# Altium schematic sheet Battery Manager.SchDoc
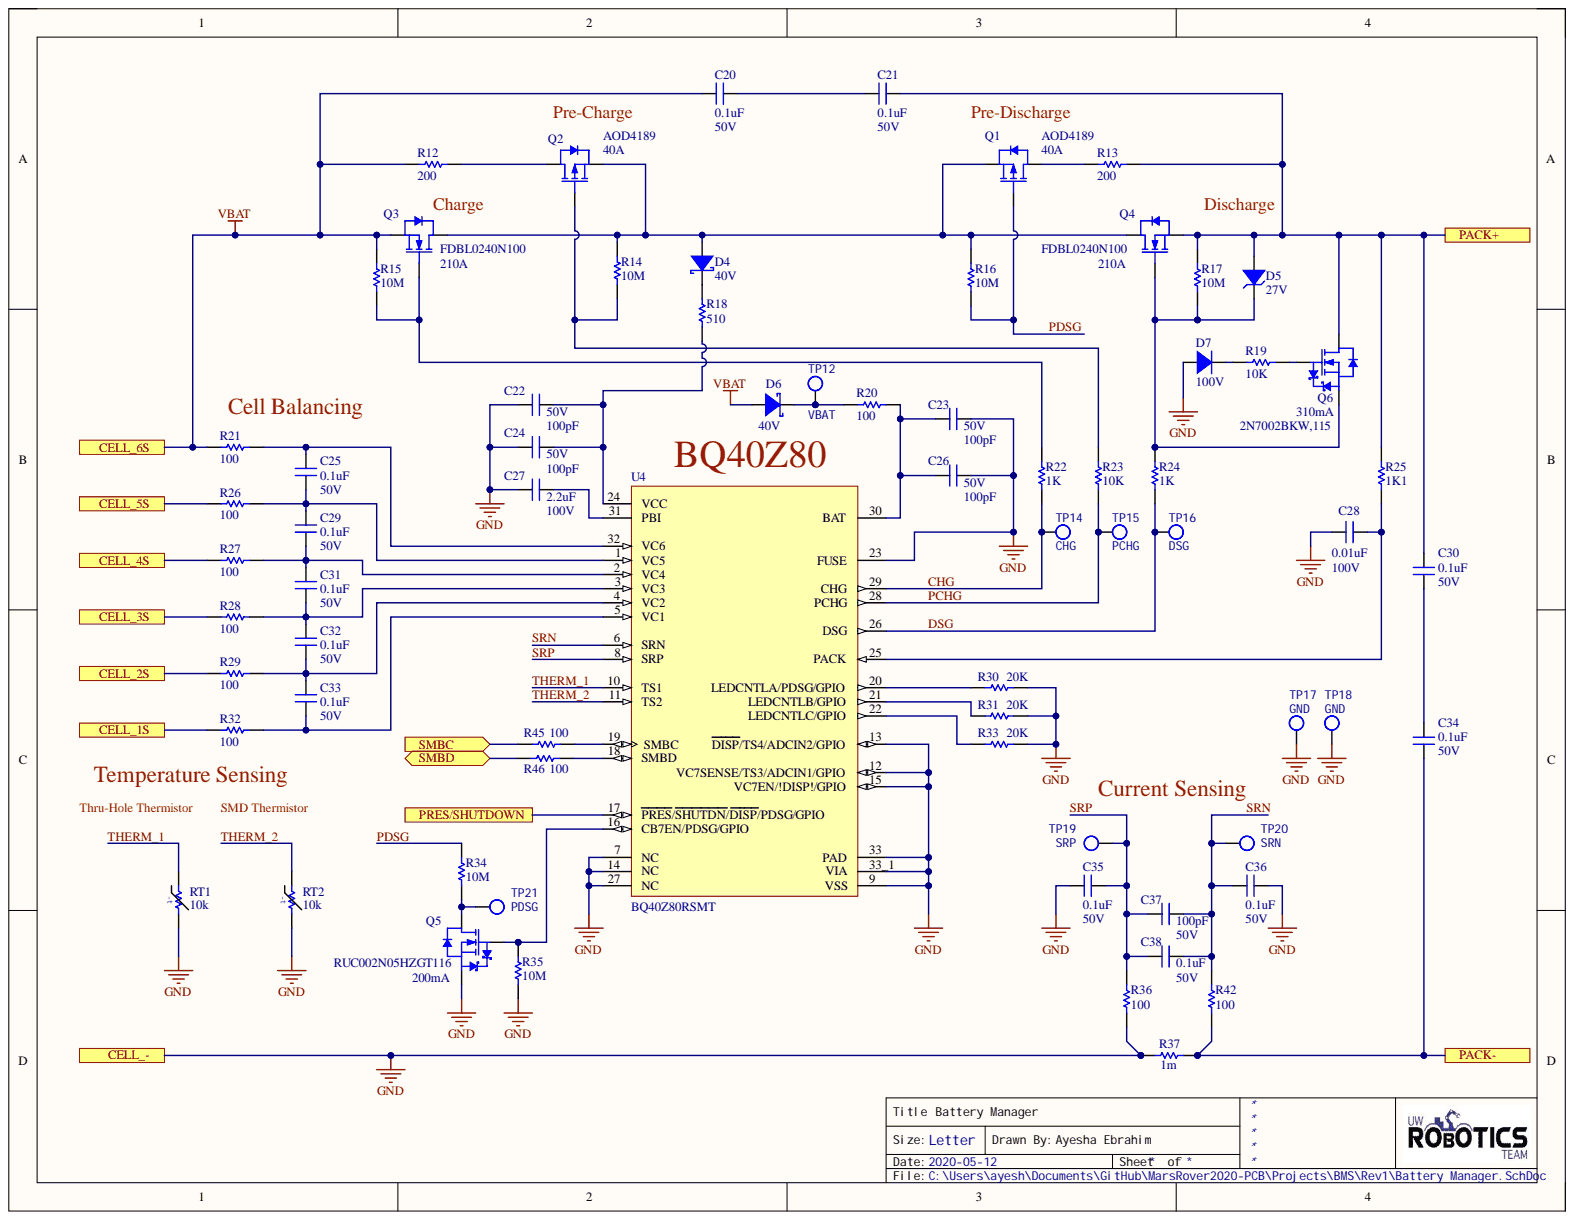
[diagram p0: Battery Manager.SchDoc]

## Parts
C20  value 0.1uF  Voltage Rating: 50V  [CAPC2012X140N]  (hidden comment: CAP_0.1uF_50V_0805)
C21  value 0.1uF  Voltage Rating: 50V  [CAPC2012X140N]  (hidden comment: CAP_0.1uF_50V_0805)
C22  value 100pF  Capacitance: 100pF  Voltage Rating: 50V  [CAPC2012X140N]  (hidden comment: CAP_100pF_50V_0805)
C23  value 100pF  Capacitance: 100pF  Voltage Rating: 50V  [CAPC2012X140N]  (hidden comment: CAP_100pF_50V_0805)
C24  value 100pF  Capacitance: 100pF  Voltage Rating: 50V  [CAPC2012X140N]  (hidden comment: CAP_100pF_50V_0805)
C25  value 0.1uF  Voltage Rating: 50V  [CAPC2012X140N]  (hidden comment: CAP_0.1uF_50V_0805)
C26  value 100pF  Capacitance: 100pF  Voltage Rating: 50V  [CAPC2012X140N]  (hidden comment: CAP_100pF_50V_0805)
C27  value 2.2uF  Capacitance: 2.2uF  Voltage Rating: 100V  [CAPC1210(3225)]  (hidden comment: CAP_2.2uF_100V_1210)
C28  value 0.01uF  Voltage Rating: 100V  [CAPC2012X140N]  (hidden comment: CAP_0.01uF_100V_0805)
C29  value 0.1uF  Voltage Rating: 50V  [CAPC2012X140N]  (hidden comment: CAP_0.1uF_50V_0805)
C30  value 0.1uF  Voltage Rating: 50V  [CAPC2012X140N]  (hidden comment: CAP_0.1uF_50V_0805)
C31  value 0.1uF  Voltage Rating: 50V  [CAPC2012X140N]  (hidden comment: CAP_0.1uF_50V_0805)
C32  value 0.1uF  Voltage Rating: 50V  [CAPC2012X140N]  (hidden comment: CAP_0.1uF_50V_0805)
C33  value 0.1uF  Voltage Rating: 50V  [CAPC2012X140N]  (hidden comment: CAP_0.1uF_50V_0805)
C34  value 0.1uF  Voltage Rating: 50V  [CAPC2012X140N]  (hidden comment: CAP_0.1uF_50V_0805)
C35  value 0.1uF  Voltage Rating: 50V  [CAPC2012X140N]  (hidden comment: CAP_0.1uF_50V_0805)
C36  value 0.1uF  Voltage Rating: 50V  [CAPC2012X140N]  (hidden comment: CAP_0.1uF_50V_0805)
C37  value 100pF  Capacitance: 100pF  Voltage Rating: 50V  [CAPC2012X140N]  (hidden comment: CAP_100pF_50V_0805)
C38  value 0.1uF  Voltage Rating: 50V  [CAPC2012X140N]  (hidden comment: CAP_0.1uF_50V_0805)
D4  Max Repetitive Reverse Voltage: 40V  [SOD123]  (hidden comment: B0540W-7-F)
D5  Working Voltage: 27V  [SOT23-3]  (hidden comment: DIO_ZENER_27V)
D6  Max Repetitive Reverse Voltage: 40V  [SOD123]  (hidden comment: B0540W-7-F)
D7  value 100V  [SOD123]  (hidden comment: 1N4148W-7-F)
Q1  Id: 40A  Manufacturer Part Number 1: AOD4189  [D-PAK]  (hidden comment: PMOS_40V_40A)
Q2  Id: 40A  Manufacturer Part Number 1: AOD4189  [D-PAK]  (hidden comment: PMOS_40V_40A)
Q3  Id: 210A  Manufacturer Part Number 1: FDBL0240N100  [8-HPSOF]  (hidden comment: NMOS_100V_210A)
Q4  Id: 210A  Manufacturer Part Number 1: FDBL0240N100  [8-HPSOF]  (hidden comment: NMOS_100V_210A)
Q5  Id: 200mA  Manufacturer Part Number 1: RUC002N05HZGT116  [SOT23-3]  (hidden comment: NMOS_50V_200mA)
Q6  Id: 310mA  Manufacturer Part Number 1: 2N7002BKW,115  [SOT323]  (hidden comment: NMOS_60V_310mA)
R12  value 200  [RESC6532X75N]  (hidden comment: RES_200R_2512)
R13  value 200  [RESC6532X75N]  (hidden comment: RES_200R_2512)
R14  value 10M  [RESC2012X60N]  (hidden comment: RES_10M_0805)
R15  value 10M  [RESC2012X60N]  (hidden comment: RES_10M_0805)
R16  value 10M  [RESC2012X60N]  (hidden comment: RES_10M_0805)
R17  value 10M  [RESC2012X60N]  (hidden comment: RES_10M_0805)
R18  value 510  [RESC2012X60N]  (hidden comment: RES_510R_0805)
R19  value 10K  Resistance: 10K  [RESC2012X60N]  (hidden comment: RES_10K_0805)
R20  value 100  [RESC2012X60N]  (hidden comment: RES_100R_0805)
R21  value 100  [RESC2012X60N]  (hidden comment: RES_100R_0805)
R22  value 1K  Resistance: 1K  [RESC2012X60N]  (hidden comment: RES_1K_0805)
R23  value 10K  Resistance: 10K  [RESC2012X60N]  (hidden comment: RES_10K_0805)
R24  value 1K  Resistance: 1K  [RESC2012X60N]  (hidden comment: RES_1K_0805)
R25  value 1K1  Resistance: 1K1  [RESC2012X60N]  (hidden comment: RES_1K1_0805)
R26  value 100  [RESC2012X60N]  (hidden comment: RES_100R_0805)
R27  value 100  [RESC2012X60N]  (hidden comment: RES_100R_0805)
R28  value 100  [RESC2012X60N]  (hidden comment: RES_100R_0805)
R29  value 100  [RESC2012X60N]  (hidden comment: RES_100R_0805)
R30  value 20K  Resistance: 20K  [RESC2012X60N]  (hidden comment: RES_20K_0805)
R31  value 20K  Resistance: 20K  [RESC2012X60N]  (hidden comment: RES_20K_0805)
R32  value 100  [RESC2012X60N]  (hidden comment: RES_100R_0805)
R33  value 20K  Resistance: 20K  [RESC2012X60N]  (hidden comment: RES_20K_0805)
R34  value 10M  [RESC2012X60N]  (hidden comment: RES_10M_0805)
R35  value 10M  [RESC2012X60N]  (hidden comment: RES_10M_0805)
R36  value 100  [RESC2012X60N]  (hidden comment: RES_100R_0805)
R37  value 1m  [RES-5931]  (hidden comment: RES_1m_5931)
R42  value 100  [RESC2012X60N]  (hidden comment: RES_100R_0805)
R45  value 100  [RESC2012X60N]  (hidden comment: RES_100R_0805)
R46  value 100  [RESC2012X60N]  (hidden comment: RES_100R_0805)
RT1  Resistance: 10k  [PTH_THERMISTOR]  (hidden comment: THERM_10k_FREE_HANGING)
RT2  Resistance: 10k  [RESC0603(1608)_N]  (hidden comment: THERM_10k_0603)
TP12  VBAT  [TESTPOINT_LOOP]
TP14  CHG  [TESTPOINT_LOOP]
TP15  PCHG  [TESTPOINT_LOOP]
TP16  DSG  [TESTPOINT_LOOP]
TP17  GND  [TESTPOINT_LOOP]
TP18  GND  [TESTPOINT_LOOP]
TP19  SRP  [TESTPOINT_LOOP]
TP20  SRN  [TESTPOINT_LOOP]
TP21  PDSG  [TESTPOINT_LOOP]
U4  BQ40Z80RSMT  [BQ40Z80RSMT]

## Ports
CELL_1S, CELL_2S, CELL_3S, CELL_4S, CELL_5S, CELL_6S, CELL_-, PACK+, PACK-, PRES/SHUTDOWN, SMBC, SMBD

## Nets
CELL_1S: R32.1 ; port CELL_1S
CELL_2S: R29.1 ; port CELL_2S
CELL_3S: R28.1 ; port CELL_3S
CELL_4S: R27.1 ; port CELL_4S
CELL_5S: R26.1 ; port CELL_5S
CHG: R22.1 TP14.1 U4.29(CHG)
DSG: R24.1 TP16.1 U4.26(DSG)
GND: C22.1 C23.2 C24.1 C26.2 C27.1 C28.1 C35.1 C36.2 D7.2 Q5.2 R30.2 R31.2 R33.2 R35.1 R36.1 R37.1 RT1.2 RT2.2 TP17.1 TP18.1 U4.7(NC) U4.9(VSS) U4.12(VC7SENSE/TS3/ADCIN1/GPIO) U4.13(DISP/TS4/ADCIN2/GPIO) U4.14(NC) U4.15(VC7EN/!DISP!/GPIO) U4.23(FUSE) U4.27(NC) U4.33(PAD) U4.33_1(VIA) ; port CELL_-
PACK+: C21.2 C30.2 D5.1 Q4.2 Q6.4 R13.2 R17.2 R25.2 ; port PACK+
PACK-: C34.1 R37.2 R42.1 ; port PACK-
PCHG: R23.1 TP15.1 U4.28(PCHG)
PDSG: Q1.2 R16.1 R34.2
PRES/SHUTDOWN: U4.17(PRES/SHUTDN/DISP/PDSG/GPIO) ; port PRES/SHUTDOWN
SMBC: R45.1 ; port SMBC
SMBD: R46.1 ; port SMBD
SRN: C36.1 C37.2 C38.2 R42.2 TP20.1 U4.6(SRN)
SRP: C35.2 C37.1 C38.1 R36.2 TP19.1 U4.8(SRP)
THERM_1: RT1.1 U4.10(TS1)
THERM_2: RT2.1 U4.11(TS2)
VBAT: C20.1 D6.2 Q3.2 R12.1 R15.2 R21.1 ; port CELL_6S
NetC20_2: C20.2 C21.1
NetC22_2: C22.2 C24.2 R18.1 U4.24(VCC)
NetC23_1: C23.1 C26.1 R20.2 U4.30(BAT)
NetC25_1: C25.1 C29.2 R26.2 U4.1(VC5)
NetC25_2: C25.2 R21.2 U4.32(VC6)
NetC27_2: C27.2 U4.31(PBI)
NetC28_2: C28.2 R25.1 U4.25(PACK)
NetC29_1: C29.1 C31.2 R27.2 U4.2(VC4)
NetC30_1: C30.1 C34.2
NetC31_1: C31.1 C32.2 R28.2 U4.3(VC3)
NetC32_1: C32.1 C33.2 R29.2 U4.4(VC2)
NetC33_1: C33.1 R32.2 U4.5(VC1)
NetD4_1: D4.1 R18.2
NetD4_2: D4.2 Q1.3 Q2.3 Q3.3 Q4.3 R14.2 R16.2
NetD5_3: D5.3 Q4.1 Q6.3 R17.1 R24.2
NetD6_1: D6.1 R20.1 TP12.1
NetD7_1: D7.1 R19.1
NetQ1_1: Q1.1 R13.1
NetQ2_1: Q2.1 R12.2
NetQ2_2: Q2.2 R14.1 R23.2
NetQ3_1: Q3.1 R15.1 R22.2
NetQ5_1: Q5.1 R35.2 U4.16(CB7EN/PDSG/GPIO)
NetQ5_3: Q5.3 R34.1 TP21.1
NetQ6_1: Q6.1 R19.2
NetR30_1: R30.1 U4.20(LEDCNTLA/PDSG/GPIO)
NetR31_1: R31.1 U4.21(LEDCNTLB/GPIO)
NetR33_1: R33.1 U4.22(LEDCNTLC/GPIO)
NetR45_2: R45.2 U4.19(SMBC)
NetR46_2: R46.2 U4.18(SMBD)

## Library data of the parts
C20 C21 C30 C34 = CAP_0.1uF_50V_0805: CAP CER 0.1UF 50V X7R 0805; Manufacturer 1: Murata; Manufacturer Part Number 1: GCD21BR71H104KA01L; Capacitance: 100nF; Tolerance: 10%; Case Code (Imperial): 0805; Case Code (Metric): 2012; Case/Package: 0805; Component Kind: Standard; ComponentLink1Description: https://datasheet.ciiva.com/29537/c03e-29537701.pdf?src-supplier=Digi-Key; ComponentLink1URL: https://datasheet.ciiva.com/29537/c03e-29537701.pdf?src-supplier=Digi-Key; Depth: 1.25mm; Dielectric: X7R; Height: 1.25mm; Length: 2mm; Max Operating Temperature: 125°C; Min Operating Temperature: -55°C; Mount: Surface Mount; Ratings: AEC-Q200; Supplier 1: Digi-Key; Supplier Part Number 1: 490-11955-1-ND; Termination: SMD/SMT; Thickness: 0.049inch; Width: 0.049inch; Library Name: UWRT_Schematic_Library_2020.SchLib
C22-C24 C26 C37 = CAP_100pF_50V_0805: CAP CER 100PF 50V C0G/NP0 0805; Manufacturer 1: KEMET; Manufacturer Part Number 1: C0805C101J5GACTU; Dielectric: C0G, NP0; Tolerance: 5%; Case Code (Imperial): 0805; Case Code (Metric): 2012; Case/Package: 0805; Component Kind: Standard; Max Operating Temperature: 125°C; Min Operating Temperature: -55°C; Mount: Surface Mount; Supplier 1: Digi-Key; Supplier Part Number 1: 399-1122-1-ND; Termination: SMD/SMT; Library Name: UWRT_Schematic_Library_2020.SchLib
C25 C29 C31-C33 C35 C36 C38 = CAP_0.1uF_50V_0805: CAP CER 0.1UF 50V X7R 0805; Manufacturer 1: Murata Electronics; Manufacturer Part Number 1: GCD21BR71H104KA01L; Capacitance: 100nF; Tolerance: 10%; Case Code (Imperial): 0805; Case Code (Metric): 2012; Case/Package: 0805; Component Kind: Standard; ComponentLink1Description: https://datasheet.ciiva.com/29537/c03e-29537701.pdf?src-supplier=Digi-Key; ComponentLink1URL: https://datasheet.ciiva.com/29537/c03e-29537701.pdf?src-supplier=Digi-Key; Depth: 1.25mm; Dielectric: X7R; Height: 1.25mm; Length: 2mm; Max Operating Temperature: 125°C; Min Operating Temperature: -55°C; Mount: Surface Mount; Ratings: AEC-Q200; Supplier 1: Digi-Key; Supplier Part Number 1: 490-11955-1-ND; Termination: SMD/SMT; Thickness: 0.049inch; Width: 0.049inch; Library Name: UWRT_Schematic_Library_2020.SchLib
C27 = CAP_2.2uF_100V_1210: CAP CER 2.2UF 100V X7R 1210; Manufacturer 1: Taiyo Yuden; Manufacturer Part Number 1: HMK325B7225KMHP; Tolerance: 10%; Case Code (Imperial): 1210; Case Code (Metric): 3225; Case/Package: 1210 (3225 Metric); Component Kind: Standard; Dielectric: X7R; Max Operating Temperature: 125°C; Min Operating Temperature: -55°C; Mount: Surface Mount; Supplier 1: Digi-Key; Supplier Part Number 1: 587-5396-1-ND; Termination: SMD/SMT; Library Name: UWRT_Schematic_Library_2020.SchLib
C28 = CAP_0.01uF_100V_0805: CAP CER 10000PF 100V X7R 0805; Manufacturer 1: KEMET; Manufacturer Part Number 1: C0805C103K1RACTU; Tolerance: 10%; Case Code (Imperial): 0805; Case Code (Metric): 2012; Case/Package: 0805; Component Kind: Standard; Dielectric: X7R; Max Operating Temperature: 125°C; Min Operating Temperature: -55°C; Mount: Surface Mount; Supplier 1: Digi-Key; Supplier Part Number 1: 399-1159-1-ND; Termination: SMD/SMT; Library Name: UWRT_Schematic_Library_2020.SchLib
D4 D6 = DIO_SCHOTTKY_40V_500mA: DIODE SCHOTTKY 40V 500MA SOD123; Manufacturer: Diodes Inc.; Manufacturer 1: Diodes Incorporated; Automotive Grade: Grade 0; Capacitance: 170pF; Forward Current: 0.5A; Max Junction Temp: +150°C; Max Surge Forward Current: 5.5A; Peak Reverse Current: 20uA; Standoff Height: 0mm; Value: 170pF; Automotive: Yes; Category: Diode; Component Kind: Standard; ComponentLink2Description: Datasheet; ComponentLink2URL: https://www.diodes.com/assets/Datasheets/ds30138.pdf; Device Class L1: Discrete Semiconductors; Device Class L2: Diodes; Device Class L3: Schottky Diodes; Digikey Description: DIODE SCHOTTKY 40V 500MA SOD123; Footprint Url: https://www.diodes.com/assets/Package-Files/SOD123.pdf; Height: 1.45mm; Lead Free: Yes; Manufacturer Part Number: B0540W-7-F; Manufacturer Part Number 1: B0540W-7-F; Mouser Description: Schottky Diodes & Rectifiers 40V 410mW; Octopart: https://octopart.com/b0540w-7-f-diodes+inc.-325656; Package: SOD123; Reverse Voltage: 40V; RoHS: TRUE; Supplier 1: Digi-Key; Supplier Part Number 1: B0540W-FDITR-ND; Temperature: -65°C to +150°C; Temperature Range High: +150°C; Temperature Range Low: -65°C; Library Name: UWRT_Schematic_Library_2020.SchLib
D5 = DIO_ZENER_27V: DIODE ZENER 27V 250MW SOT23; Manufacturer 1: Nexperia USA Inc.; Manufacturer Part Number 1: BZX84-C27,215; Forward Voltage: 0.9V; Tolerance: 5%; Component Kind: Standard; Mount: Surface Mount; Package/Case: SOT-23-3; Supplier 1: Digi-Key; Supplier Part Number 1: 1727-5026-2-ND; Library Name: UWRT_Schematic_Library_2020.SchLib
D7 = DIO_100V_300mA: DIODE GEN PURP 100V 300MA SOD123; Manufacturer 1: Diodes Incorporated; Component Kind: Standard; Device Class L2: Diodes; Manufacturer Part Number 1: 1N4148W-7-F; Supplier 1: Digi-Key; Supplier Part Number 1: 1N4148W-FDICT-ND; Library Name: UWRT_Schematic_Library_2020.SchLib
Q1 Q2 = PMOS_40V_40A: MOSFET P-CH 40V 40A TO252; Manufacturer 1: Alpha & Omega Semiconductor Inc.; FET Type: P-Channel; Power Dissipation (Max): 62.5W; Vgs (Max): 20V; Component Kind: Standard; Supplier 1: Digi-Key; Supplier Device Package: D-PAK (TO-252); Supplier Part Number 1: 785-1351-1-ND; Vdss: 40V; Library Name: UWRT_Schematic_Library_2020.SchLib
Q3 Q4 = NMOS_100V_210A: MOSFET N-CH 100V 210A POWER56; Manufacturer 1: ON Semiconductor; FET Type: N-Channel; Power Dissipation (Max): 300W; Vgs (Max): 20V; Component Kind: Standard; Supplier 1: Digi-Key; Supplier Device Package: 8-HPSOF; Supplier Part Number 1: FDBL0240N100CT-ND; Vdss: 100V; Library Name: UWRT_Schematic_Library_2020.SchLib
Q5 = NMOS_50V_200mA: NMOS N-CH 50V 200mA 1.2V DRIVE SOT-23-3; Manufacturer 1: Rohm Semiconductor; Component Kind: Standard; Supplier 1: Digi-Key; Supplier Part Number 1: RUC002N05HZGT116CT-ND; Library Name: UWRT_Schematic_Library_2020.SchLib
Q6 = NMOS_60V_310mA: NMOS N-CH 60V 310mA SOT-323; Manufacturer 1: Nexperia; Component Kind: Standard; ComponentLink1Description: https://www.mouser.com/ds/2/302/2N7002BKW-1150600.pdf?src-supplier=Mouser; ComponentLink1URL: https://www.mouser.com/ds/2/302/2N7002BKW-1150600.pdf?src-supplier=Mouser; ComponentLink2Description: http://www.farnell.com/datasheets/1322445.pdf?src-supplier=Farnell; ComponentLink2URL: http://www.farnell.com/datasheets/1322445.pdf?src-supplier=Farnell; ComponentLink3Description: https://assets.nexperia.com/documents/data-sheet/2N7002BKW.pdf?src-supplier=Digi-Key; ComponentLink3URL: https://assets.nexperia.com/documents/data-sheet/2N7002BKW.pdf?src-supplier=Digi-Key; ComponentLink4Description: http://www.farnell.com/datasheets/1322445.pdf?src-supplier=Newark; ComponentLink4URL: http://www.farnell.com/datasheets/1322445.pdf?src-supplier=Newark; Pricing 1: 1=0.36000, 10=0.29600, 25=0.24760, 100=0.12100, 250=0.11908, 500=0.10092, 1000=0.07013 (USD); RoHS: No; Stock 1: 127361; Supplier 1: Digi-Key; Supplier Part Number 1: 1727-4788-1-ND; Library Name: UWRT_Schematic_Library_2020.SchLib
R12 R13 = RES_200R_2512: RES 200 OHM 5% 1W 2512; Manufacturer 1: Stackpole Electronics Inc; Manufacturer Part Number 1: RMCF2512JT200R; Component Kind: Standard; Dimensions: 0.250" L x 0.125" W (6.35mm x 3.18mm); Mount: Surface Mount; Package: 2512 (6432 Metric); Supplier 1: Digi-Key; Supplier Part Number 1: RMCF2512JT200RCT-ND; Tolerance: 5%; Library Name: UWRT_Schematic_Library_2020.SchLib
R14-R17 R34 R35 = RES_10M_0805: RES SMD 10M OHM 5% 1/8W 0805; Manufacturer 1: Yageo; Manufacturer Part Number 1: RC0805JR-0710ML; Component Kind: Standard; Mount: Surface Mount; Package: 0805 (2012 Metric); Signal Integrity: Res; Simulation: RESISTOR; Supplier 1: Digi-Key; Supplier Part Number 1: 311-10MARCT-ND; Tolerance: 5%; Library Name: UWRT_Schematic_Library_2020.SchLib
R18 = RES_510R_0805: RES SMD 510 OHM 1% 1/8W 0805; Manufacturer 1: Yageo; Manufacturer Part Number 1: RC0805FR-07510RL; Power Rating: 125mW; Resistance: 510R; Case Code (Imperial): 0805; Case Code (Metric): 2012; Component Kind: Standard; Max Operating Temperature: 155°C; Min Operating Temperature: -55°C; Supplier 1: Digi-Key; Supplier Part Number 1: 311-510CRCT-ND; Termination: SMD/SMT; Tolerance: 1%; Library Name: UWRT_Schematic_Library_2020.SchLib
R19 R23 = RES_10K_0805: RES SMD 10K OHM 1% 1/8W 0805; Manufacturer 1: Yageo; Manufacturer Part Number 1: RC0805FR-0710KL; Power Rating: 125mW; Case Code (Imperial): 0805; Case Code (Metric): 2012; Component Kind: Standard; Max Operating Temperature: 155°C; Min Operating Temperature: -55°C; Supplier 1: Digi-Key; Supplier Part Number 1: 311-10.0KCRCT-ND; Termination: SMD/SMT; Tolerance: 1%; Library Name: UWRT_Schematic_Library_2020.SchLib
R20 R21 R26-R29 R32 R36 R42 R45 R46 = RES_100R_0805: RES SMD 100 OHM 1% 1/8W 0805; Manufacturer 1: Yageo; Manufacturer Part Number 1: RC0805FR-07100RL; Power Rating: 125mW; Case Code (Imperial): 0805; Case Code (Metric): 2012; Component Kind: Standard; Mount: Surface Mount; Supplier 1: Digi-Key; Supplier Part Number 1: 311-100CRCT-ND; Library Name: UWRT_Schematic_Library_2020.SchLib
R22 R24 = RES_1K_0805: RES SMD 1K OHM 1% 1/8W 0805; Manufacturer 1: Yageo; Manufacturer Part Number 1: RC0805FR-071KL; Power Rating: 125mW; Case Code (Imperial): 0805; Case Code (Metric): 2012; Component Kind: Standard; Max Operating Temperature: 155°C; Min Operating Temperature: -55°C; Supplier 1: Digi-Key; Supplier Part Number 1: 311-1.00KCRCT-ND; Termination: SMD/SMT; Tolerance: 1%; Library Name: UWRT_Schematic_Library_2020.SchLib
R25 = RES_1K1_0805: RES SMD 1.1K OHM 1% 1/8W 0805; Manufacturer 1: Yageo; Manufacturer Part Number 1: RC0805FR-071K1L; Power Rating: 125mW; Case Code (Imperial): 0805; Case Code (Metric): 2012; Component Kind: Standard; Max Operating Temperature: 155°C; Min Operating Temperature: -55°C; Mount: Surface Mount; Supplier 1: Digi-Key; Supplier Part Number 1: 311-1.10KCRCT-ND; Termination: SMD/SMT; Tolerance: 1%; Library Name: UWRT_Schematic_Library_2020.SchLib
R30 R31 R33 = RES_20K_0805: RES SMD 20K OHM 1% 1/8W 0805; Manufacturer 1: Yageo; Manufacturer Part Number 1: RC0805FR-0720KL; Power Rating: 125mW; Case Code (Imperial): 0805; Case Code (Metric): 2012; Component Kind: Standard; Max Operating Temperature: 155°C; Min Operating Temperature: -55°C; Supplier 1: Digi-Key; Supplier Part Number 1: 311-20.0KCRCT-ND; Termination: SMD/SMT; Tolerance: 1%; Library Name: UWRT_Schematic_Library_2020.SchLib
R37 = RES_1m_5931: RES 0.001 OHM 1% 10W 5931; Manufacturer 1: Vishay Dale; Manufacturer Part Number 1: WSLP59311L000FEA; Component Kind: Standard; Dimensions: 0.591" L x 0.305" W (15.00mm x 7.75mm); Mount: Surface Mount; Package: 5931; Signal Integrity: Res; Simulation: RESISTOR; Supplier 1: Digi-Key; Supplier Part Number 1: 541-10012-1-ND; Tolerance: 1%; Library Name: UWRT_Schematic_Library_2020.SchLib
RT1 = THERM_10k_FREE_HANGING: THERMISTOR NTC 10KOHM 3380K BEAD; Manufacturer 1: Murata; Manufacturer Part Number 1: NXFT15XH103FA2B100; Current Rating: 120uA; Lead Diameter: 0.3mm; Max Power Dissipation: 100mW; Power Rating: 7.5mW; Tolerance: 1%; Case/Package: Radial; Category: Interface ICs; Component Kind: Standard; ComponentLink1Description: https://datasheet.ciiva.com/2849/r44e-2849356.pdf?src-supplier=Digi-Key; ComponentLink1URL: https://datasheet.ciiva.com/2849/r44e-2849356.pdf?src-supplier=Digi-Key; ComponentLink2Description: https://datasheet.ciiva.com/3282/r44e-3282445.pdf?src-supplier=Avnet; ComponentLink2URL: https://datasheet.ciiva.com/3282/r44e-3282445.pdf?src-supplier=Avnet; Max Operating Temperature: 125°C; Min Operating Temperature: -40°C; Mount: Free Hanging,Through Hole; Number of Pins: 2; Package Quantity: 1000; Packaging: Bulk; Pricing 1: 1=0.91000, 5=0.86400, 10=0.75200, 25=0.66080, 50=0.54900, 100=0.46760, 500=0.40660, 1000=0.35578, 5000=0.31512 (USD); RoHS: Yes; Stock 1: 19053; Supplier 1: Digi-Key; Termination: Wire; Library Name: UWRT_Schematic_Library_2020.SchLib
RT2 = THERM_10k_0603: THERMISTOR NTC 10K 1% OHM 0603; Manufacturer 1: TDK; Manufacturer Part Number 1: NTCG163JF103FT1; Accuracy: 1%; Case Code (Imperial): 0603; Case Code (Metric): 1608; Case/Package: 0603; Component Kind: Standard; ComponentLink1Description: https://datasheet.ciiva.com/11822/0900766b80feea16-11822415.pdf?src-supplier=RSComponents; ComponentLink1URL: https://datasheet.ciiva.com/11822/0900766b80feea16-11822415.pdf?src-supplier=RSComponents; ComponentLink2Description: https://datasheet.ciiva.com/2848/ntcg163jf103ft1-web-2848612.pdf?src-supplier=Digi-Key; ComponentLink2URL: https://datasheet.ciiva.com/2848/ntcg163jf103ft1-web-2848612.pdf?src-supplier=Digi-Key; ComponentLink3Description: https://datasheet.ciiva.com/2848/ntcg163jf103ft1-web-2848610.pdf?src-supplier=Digi-Key; ComponentLink3URL: https://datasheet.ciiva.com/2848/ntcg163jf103ft1-web-2848610.pdf?src-supplier=Digi-Key; ComponentLink4Description: https://datasheet.ciiva.com/2848/ntcg163jf103ft1-web-2848611.pdf?src-supplier=Digi-Key; ComponentLink4URL: https://datasheet.ciiva.com/2848/ntcg163jf103ft1-web-2848611.pdf?src-supplier=Digi-Key; Depth: 0.8mm; Height: 0.8mm; Lead Free: Lead Free; Length: 1.6mm; Max Operating Temperature: 125°C; Min Operating Temperature: -40°C; Mount: Surface Mount; Number of Pins: 2; Package Quantity: 4000; Packaging: Tape and Reel; Pricing 1: 1=0.25000, 5=0.23600, 10=0.20900, 25=0.19240, 50=0.15400, 100=0.13200, 500=0.11550, 1000=0.09900 (USD); Radiation Hardening: No; RoHS: Yes; Stock 1: 94107; Supplier 1: Digi-Key; Supplier Part Number 1: 445-2554-1-ND; Tolerance: 1%; Library Name: UWRT_Schematic_Library_2020.SchLib
TP12 TP14-TP21 = TESTPOINT_LOOP: Loop testpoint through-hole pad; Manufacturer 1: Keystone Electronics; Manufacturer Part Number 1: 5002; Component Kind: Standard; Mount: Through Hole; Supplier 1: Digi-Key; Supplier Part Number 1: 36-5002-ND; Library Name: UWRT_Schematic_Library_2020.SchLib
U4 = BATT_MANAGER: POWER MANAGEMENT IC: Battery Multi-Function Controller IC Lithium Ion/Polymer 32-VQFN (4x4); Manufacturer 1: Texas Instruments; Component Kind: Standard; Manufacturer Part Number 1: BQ40Z80RSMT; SnapEDA_Link: https://www.snapeda.com/parts/BQ40Z80RSMT/Texas%20Instruments/view-part/2782044/?ref=snap; Supplier 1: Digi-Key; Supplier Part Number 1: 296-BQ40Z80RSMTCT-ND; Library Name: UWRT_Schematic_Library_2020.SchLib
